# Altium schematic sheet FPGA02.SchDoc
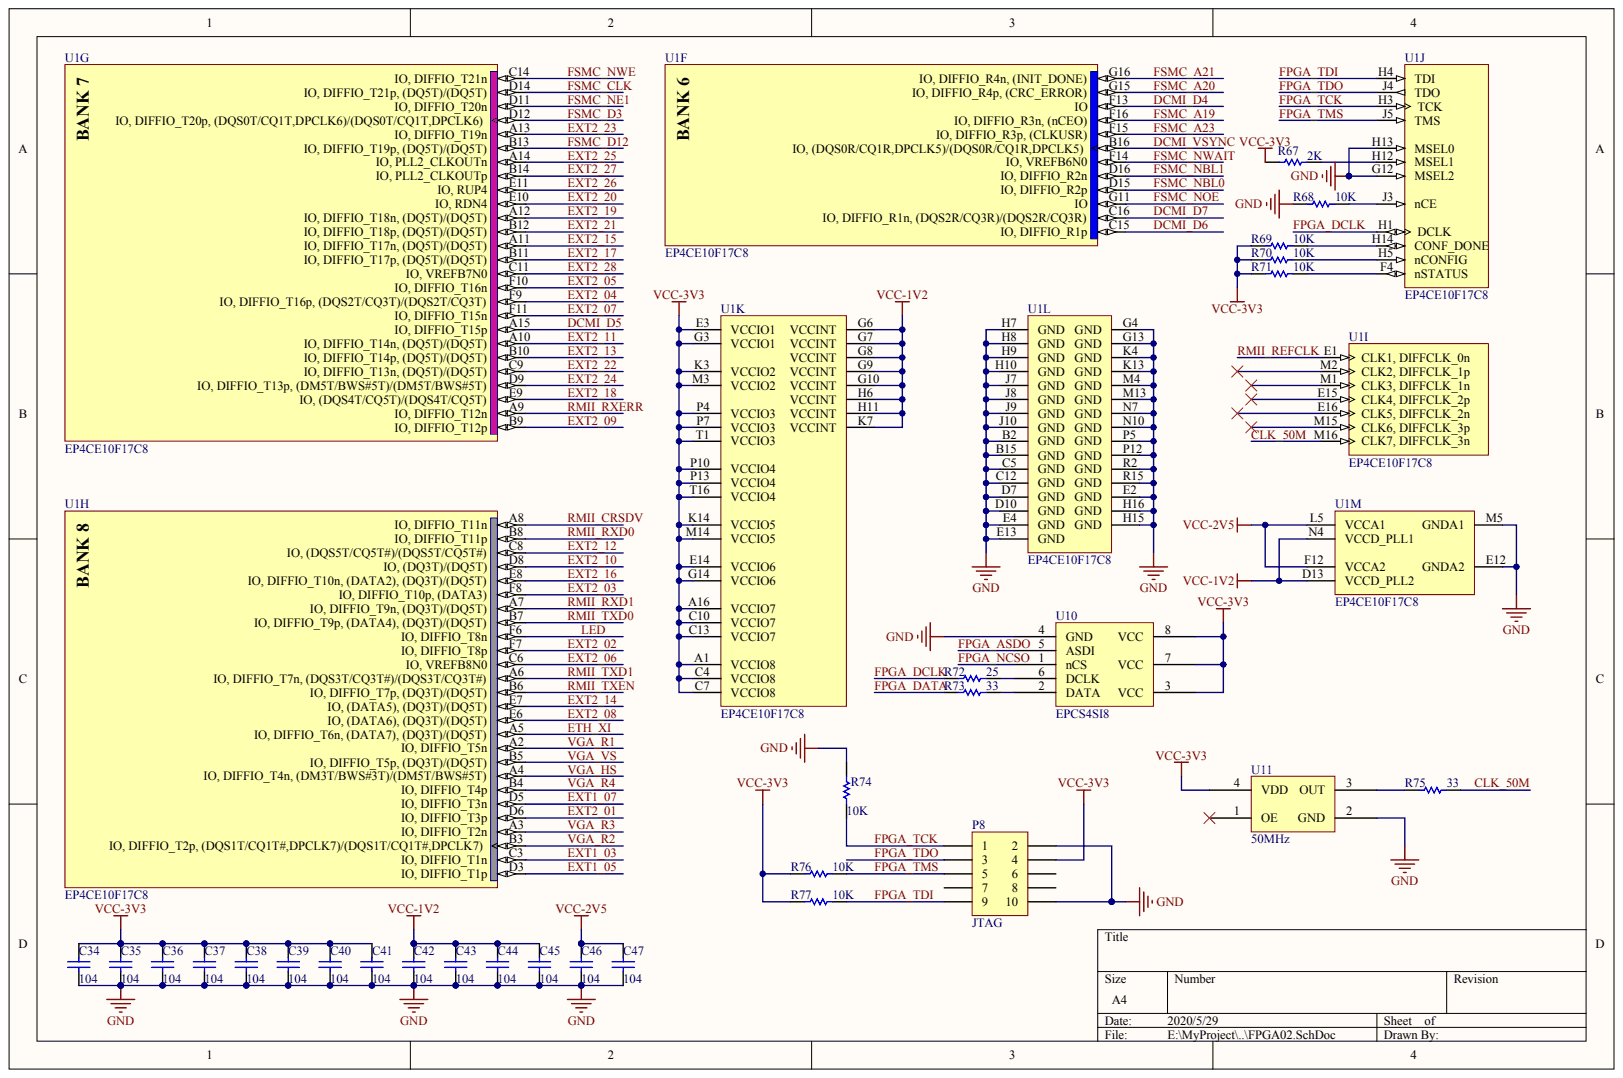
[diagram p0: FPGA02.SchDoc]

## Parts
C34  value 104  (hidden comment: Cap)
C35  value 104  (hidden comment: Cap)
C36  value 104  (hidden comment: Cap)
C37  value 104  (hidden comment: Cap)
C38  value 104  (hidden comment: Cap)
C39  value 104  (hidden comment: Cap)
C40  value 104  (hidden comment: Cap)
C41  value 104  (hidden comment: Cap)
C42  value 104  (hidden comment: Cap)
C43  value 104  (hidden comment: Cap)
C44  value 104  (hidden comment: Cap)
C45  value 104  (hidden comment: Cap)
C46  value 104  (hidden comment: Cap)
C47  value 104  (hidden comment: Cap)
P8  JTAG
R67  value 2K  (hidden comment: Res1)
R68  value 10K  (hidden comment: Res1)
R69  value 10K  (hidden comment: Res1)
R70  value 10K  (hidden comment: Res1)
R71  value 10K  (hidden comment: Res1)
R72  value 25  (hidden comment: Res1)
R73  value 33  (hidden comment: Res1)
R74  value 10K  (hidden comment: Res1)
R75  value 33  (hidden comment: Res1)
R76  value 10K  (hidden comment: Res1)
R77  value 10K  (hidden comment: Res1)
U1  EP4CE10F17C8  (parts F,G,H,I,J,K,L,M)
U10  EPCS4SI8
U11  50MHz

## Nets
GND: C34.2 C35.2 C36.2 C37.2 C38.2 C39.2 C40.2 C41.2 C42.2 C43.2 C44.2 C45.2 C46.2 C47.2 P8.2 P8.10 R68.2 R74.2 U1.B2(GND) U1.B15(GND) U1.C5(GND) U1.C12(GND) U1.D7(GND) U1.D10(GND) U1.E2(GND) U1.E4(GND) U1.E12(GNDA2) U1.E13(GND) U1.G4(GND) U1.G12(MSEL2) U1.G13(GND) U1.H7(GND) U1.H8(GND) U1.H9(GND) U1.H10(GND) U1.H13(MSEL0) U1.H15(GND) U1.H16(GND) U1.J7(GND) U1.J8(GND) U1.J9(GND) U1.J10(GND) U1.K4(GND) U1.K13(GND) U1.M4(GND) U1.M5(GNDA1) U1.M13(GND) U1.N7(GND) U1.N10(GND) U1.P5(GND) U1.P12(GND) U1.R2(GND) U1.R15(GND) U10.4(GND) U11.2(GND)
VCC-1V2: C42.1 C43.1 C44.1 C45.1 U1.D13(VCCD_PLL2) U1.G6(VCCINT) U1.G7(VCCINT) U1.G8(VCCINT) U1.G9(VCCINT) U1.G10(VCCINT) U1.H6(VCCINT) U1.H11(VCCINT) U1.K7(VCCINT) U1.N4(VCCD_PLL1)
VCC-2V5: C46.1 C47.1 U1.F12(VCCA2) U1.L5(VCCA1)
VCC-3V3: C34.1 C35.1 C36.1 C37.1 C38.1 C39.1 C40.1 C41.1 P8.4 R67.1 R69.2 R70.2 R71.2 R76.2 R77.2 U1.A1(VCCIO8) U1.A16(VCCIO7) U1.C4(VCCIO8) U1.C7(VCCIO8) U1.C10(VCCIO7) U1.C13(VCCIO7) U1.E3(VCCIO1) U1.E14(VCCIO6) U1.G3(VCCIO1) U1.G14(VCCIO6) U1.K3(VCCIO2) U1.K14(VCCIO5) U1.M3(VCCIO2) U1.M14(VCCIO5) U1.P4(VCCIO3) U1.P7(VCCIO3) U1.P10(VCCIO4) U1.P13(VCCIO4) U1.T1(VCCIO3) U1.T16(VCCIO4) U10.3(VCC) U10.7(VCC) U10.8(VCC) U11.4(VDD)
CLK_50M: R75.1 U1.M16(CLK7,_DIFFCLK_3n)
DCMI_D4: U1.F13(IO)
DCMI_D5: U1.A15(IO,_DIFFIO_T15p)
DCMI_D6: U1.C15(IO,_DIFFIO_R1p)
DCMI_D7: U1.C16(IO,_DIFFIO_R1n,_(DQS2R/CQ3R)/(DQS2R/CQ3R))
DCMI_VSYNC: U1.B16(IO,_(DQS0R/CQ1R,DPCLK5)/(DQS0R/CQ1R,DPCLK5))
ETH_XI: U1.A5(IO,_DIFFIO_T6n,_(DATA7),_(DQ3T)/(DQ5T))
EXT1_03: U1.C3(IO,_DIFFIO_T1n)
EXT1_05: U1.D3(IO,_DIFFIO_T1p)
EXT1_07: U1.D5(IO,_DIFFIO_T3n)
EXT2_01: U1.D6(IO,_DIFFIO_T3p)
EXT2_02: U1.F7(IO,_DIFFIO_T8p)
EXT2_03: U1.F8(IO,_DIFFIO_T10p,_(DATA3))
EXT2_04: U1.F9(IO,_DIFFIO_T16p,_(DQS2T/CQ3T)/(DQS2T/CQ3T))
EXT2_05: U1.F10(IO,_DIFFIO_T16n)
EXT2_06: U1.C6(IO,_VREFB8N0)
EXT2_07: U1.F11(IO,_DIFFIO_T15n)
EXT2_08: U1.E6(IO,_(DATA6),_(DQ3T)/(DQ5T))
EXT2_09: U1.B9(IO,_DIFFIO_T12p)
EXT2_10: U1.D8(IO,_(DQ3T)/(DQ5T))
EXT2_11: U1.A10(IO,_DIFFIO_T14n,_(DQ5T)/(DQ5T))
EXT2_12: U1.C8(IO,_(DQS5T/CQ5T#)/(DQS5T/CQ5T#))
EXT2_13: U1.B10(IO,_DIFFIO_T14p,_(DQ5T)/(DQ5T))
EXT2_14: U1.E7(IO,_(DATA5),_(DQ3T)/(DQ5T))
EXT2_15: U1.A11(IO,_DIFFIO_T17n,_(DQ5T)/(DQ5T))
EXT2_16: U1.E8(IO,_DIFFIO_T10n,_(DATA2),_(DQ3T)/(DQ5T))
EXT2_17: U1.B11(IO,_DIFFIO_T17p,_(DQ5T)/(DQ5T))
EXT2_18: U1.E9(IO,_(DQS4T/CQ5T)/(DQS4T/CQ5T))
EXT2_19: U1.A12(IO,_DIFFIO_T18n,_(DQ5T)/(DQ5T))
EXT2_20: U1.E10(IO,_RDN4)
EXT2_21: U1.B12(IO,_DIFFIO_T18p,_(DQ5T)/(DQ5T))
EXT2_22: U1.C9(IO,_DIFFIO_T13n,_(DQ5T)/(DQ5T))
EXT2_23: U1.A13(IO,_DIFFIO_T19n)
EXT2_24: U1.D9(IO,_DIFFIO_T13p,_(DM5T/BWS#5T)/(DM5T/BWS#5T))
EXT2_25: U1.A14(IO,_PLL2_CLKOUTn)
EXT2_26: U1.E11(IO,_RUP4)
EXT2_27: U1.B14(IO,_PLL2_CLKOUTp)
EXT2_28: U1.C11(IO,_VREFB7N0)
FPGA_ASDO: U10.5(ASDI)
FPGA_DATA: R73.2
FPGA_DCLK: R72.2 U1.H1(DCLK)
FPGA_NCSO: U10.1(nCS)
FPGA_TCK: P8.1 R74.1 U1.H3(TCK)
FPGA_TDI: P8.9 R77.1 U1.H4(TDI)
FPGA_TDO: P8.3 U1.J4(TDO)
FPGA_TMS: P8.5 R76.1 U1.J5(TMS)
FSMC_A19: U1.F16(IO,_DIFFIO_R3n,_(nCEO))
FSMC_A20: U1.G15(IO,_DIFFIO_R4p,_(CRC_ERROR))
FSMC_A21: U1.G16(IO,_DIFFIO_R4n,_(INIT_DONE))
FSMC_A23: U1.F15(IO,_DIFFIO_R3p,_(CLKUSR))
FSMC_CLK: U1.D14(IO,_DIFFIO_T21p,_(DQ5T)/(DQ5T))
FSMC_D3: U1.D12(IO,_DIFFIO_T20p,_(DQS0T/CQ1T,DPCLK6)/(DQS0T/CQ1T,DPCLK6))
FSMC_D12: U1.B13(IO,_DIFFIO_T19p,_(DQ5T)/(DQ5T))
FSMC_NBL0: U1.D15(IO,_DIFFIO_R2p)
FSMC_NBL1: U1.D16(IO,_DIFFIO_R2n)
FSMC_NE1: U1.D11(IO,_DIFFIO_T20n)
FSMC_NOE: U1.G11(IO)
FSMC_NWAIT: U1.F14(IO,_VREFB6N0)
FSMC_NWE: U1.C14(IO,_DIFFIO_T21n)
LED: U1.F6(IO,_DIFFIO_T8n)
RMII_CRSDV: U1.A8(IO,_DIFFIO_T11n)
RMII_REFCLK: U1.E1(CLK1,_DIFFCLK_0n)
RMII_RXD0: U1.B8(IO,_DIFFIO_T11p)
RMII_RXD1: U1.A7(IO,_DIFFIO_T9n,_(DQ3T)/(DQ5T))
RMII_RXERR: U1.A9(IO,_DIFFIO_T12n)
RMII_TXD0: U1.B7(IO,_DIFFIO_T9p,_(DATA4),_(DQ3T)/(DQ5T))
RMII_TXD1: U1.A6(IO,_DIFFIO_T7n,_(DQS3T/CQ3T#)/(DQS3T/CQ3T#))
RMII_TXEN: U1.B6(IO,_DIFFIO_T7p,_(DQ3T)/(DQ5T))
VGA_HS: U1.A4(IO,_DIFFIO_T4n,_(DM3T/BWS#3T)/(DM5T/BWS#5T))
VGA_R1: U1.A2(IO,_DIFFIO_T5n)
VGA_R2: U1.B3(IO,_DIFFIO_T2p,_(DQS1T/CQ1T#,DPCLK7)/(DQS1T/CQ1T#,DPCLK7))
VGA_R3: U1.A3(IO,_DIFFIO_T2n)
VGA_R4: U1.B4(IO,_DIFFIO_T4p)
VGA_VS: U1.B5(IO,_DIFFIO_T5p,_(DQ3T)/(DQ5T))
NetR67_2: R67.2 U1.H12(MSEL1)
NetR68_1: R68.1 U1.J3(nCE)
NetR69_1: R69.1 U1.H14(CONF_DONE)
NetR70_1: R70.1 U1.H5(nCONFIG)
NetR71_1: R71.1 U1.F4(nSTATUS)
NetR72_1: R72.1 U10.6(DCLK)
NetR73_1: R73.1 U10.2(DATA)
NetR75_2: R75.2 U11.3(OUT)

Not connected: P8 6 7 8; U1 E15(CLK4,_DIFFCLK_2p) E16(CLK5,_DIFFCLK_2n) M1(CLK3,_DIFFCLK_1n) M2(CLK2,_DIFFCLK_1p) M15(CLK6,_DIFFCLK_3p); U11 1(OE)

## Library data of the parts
C34-C47 P8 R67-R77 U1 U10 U11 = (no symbol name)
  C34-C47: Published: 8-Jun-2000; LatestRevisionDate: 17-Jul-2002; LatestRevisionNote: Re-released for DXP Platform.; PackageReference: RAD-0.3; PackageDescription: Radial Cap, Thru-Hole; 2 Leads; 0.3 in Pin Spacing
  P8: LatestRevisionDate: 17-Jul-2002; LatestRevisionNote: Re-released for DXP Platform.
  R67-R77: Published: 8-Jun-2000; LatestRevisionDate: 17-Jul-2002; LatestRevisionNote: Re-released for DXP Platform.; PackageReference: AXIAL-0.3; PackageDescription: Axial Device, Thru-Hole; 2 Leads; 0.3 in Pin Spacing
  U1: Published: 3-May-2010; DatasheetVersion: ver1.2, Mar-2010; PackageVersion: ver16.0, Dec-2009; PackageReference: FBGA256; PackageDescription: 256-Ball FineLine Ball Grid Array (FBGA256) - Option 1 - Wire Bond; Supplier 1: Digi-Key; Supplier Part Number 1: EP4CE10F17C8-ND; Code_JEDEC: MS-034-AAF-1; Code_IPC: BGA100P16X16-256; ComponentLink1Description: Manufacturer Link; ComponentLink1URL: http://www.altera.com/; ComponentLink2Description: Handbook; ComponentLink2URL: http://www.altera.com/literature/hb/cyclone-iv/cyclone4-handbook.pdf; ComponentLink3Description: Pinout Specification; ComponentLink3URL: http://www.altera.com/literature/lit-dp.jsp?category=cyc%204&showspreadsheet=y; ComponentLink4Description: Packaging Specification; ComponentLink4URL: http://www.altera.com/literature/ds/dspkg.pdf
  C34-C47 P8 R67-R77 U1: Publisher: Altium Limited
